# Altium schematic sheet analog.SchDoc(analog)
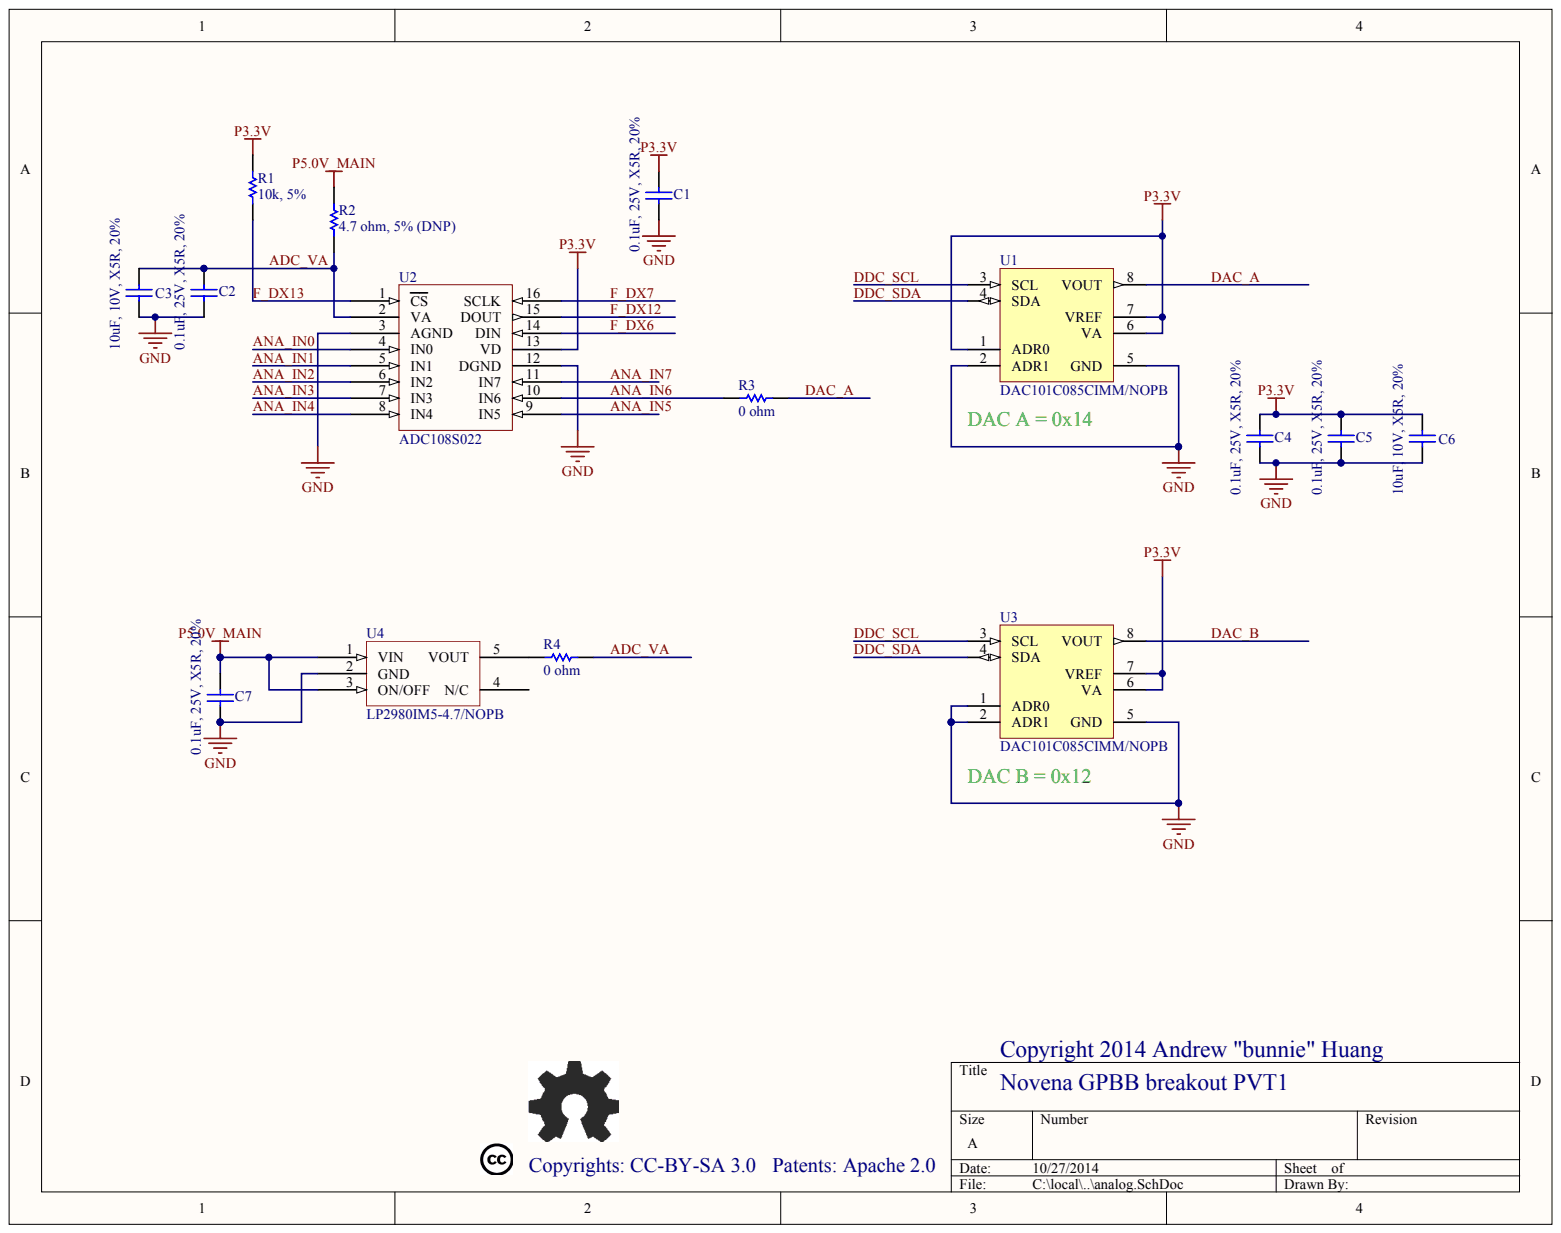
[diagram p0: analog.SchDoc]

## Parts
C1  0.1uF, 25V, X5R, 20%
C2  0.1uF, 25V, X5R, 20%
C3  10uF, 10V, X5R, 20%
C4  0.1uF, 25V, X5R, 20%
C5  0.1uF, 25V, X5R, 20%
C6  10uF, 10V, X5R, 20%
C7  0.1uF, 25V, X5R, 20%
R1  10k, 5%
R2  4.7 ohm, 5% (DNP)
R3  0 ohm
R4  0 ohm
U1  DAC101C085CIMM/NOPB
U2  ADC108S022
U3  DAC101C085CIMM/NOPB
U4  LP2980IM5-4.7/NOPB

## Nets
GND: C1.2 C2.2 C3.2 C4.2 C5.2 C6.2 C7.2 U1.2(ADR1) U1.5(GND) U2.3(AGND) U2.12(DGND) U3.1(ADR0) U3.2(ADR1) U3.5(GND) U4.2(GND)
P3.3V: C1.1 C4.1 C5.1 C6.1 R1.1 U1.1(ADR0) U1.6(VA) U1.7(VREF) U2.13(VD) U3.6(VA) U3.7(VREF)
P5.0V_MAIN: C7.1 R2.2 U4.1(VIN) U4.3(ON/OFF)
ADC_VA: C2.1 C3.1 R2.1 R4.1 U2.2(VA)
ANA_IN0: U2.4(IN0)
ANA_IN1: U2.5(IN1)
ANA_IN2: U2.6(IN2)
ANA_IN3: U2.7(IN3)
ANA_IN4: U2.8(IN4)
ANA_IN5: U2.9(IN5)
ANA_IN6: R3.1 U2.10(IN6)
ANA_IN7: U2.11(IN7)
DAC_A: R3.2 U1.8(VOUT)
DAC_B: U3.8(VOUT)
DDC_SCL: U1.3(SCL) U3.3(SCL)
DDC_SDA: U1.4(SDA) U3.4(SDA)
F_DX6: U2.14(DIN)
F_DX7: U2.16(SCLK)
F_DX12: U2.15(DOUT)
F_DX13: R1.2 U2.1(CS)
NetR4_2: R4.2 U4.5(VOUT)

Not connected: U4 4(N/C)

## Library data of the parts
R1-R4 = (no symbol name): Value: 100k; Published: 8-Jun-2000; LatestRevisionDate: 17-Jul-2002; LatestRevisionNote: Re-released for DXP Platform.; PackageReference: AXIAL-0.3; Publisher: Altium Limited
U1 U3 = (no symbol name): Manufacturer: National Semiconductor; PackageTopMarking: ZXTT*X91C; PowerWise: N; CompType: D/A Converter; High-Reliability: Y; DatasheetVersion: Mar-2008; PackageVersion: revF, Apr-2008; PackageReference: MUA08A; PackageDescription: MINI SOIC, .118 x .118 x .034in, 8 Lead, .0256in Pitch; PartNumber: DAC101C085CIMM/NOPB; RoHS: Y; PinCount: 8; Code_JEDEC: MO-187-AA; ComponentLink1Description: Manufacturer URL; ComponentLink1URL: http://www.national.com/; ComponentLink2Description: DAC101C085 Datasheet; ComponentLink2URL: http://www.national.com/ds/DA/DAC101C085.pdf; ComponentLink3Description: DAC101C085 Web Page; ComponentLink3URL: http://www.national.com/pf/DA/DAC101C085.html; ComponentLink4Description: MUA08A Package Page; ComponentLink4URL: http://www.national.com/packaging/folders/mua08a.html
C1-C7 U2 U4 = (no symbol name)
  C1-C7: Published: 8-Jun-2000; LatestRevisionDate: 17-Jul-2002; LatestRevisionNote: Re-released for DXP Platform.; PackageReference: C1206; Publisher: Altium Limited
